# Altium schematic sheet Connectors.SchDoc
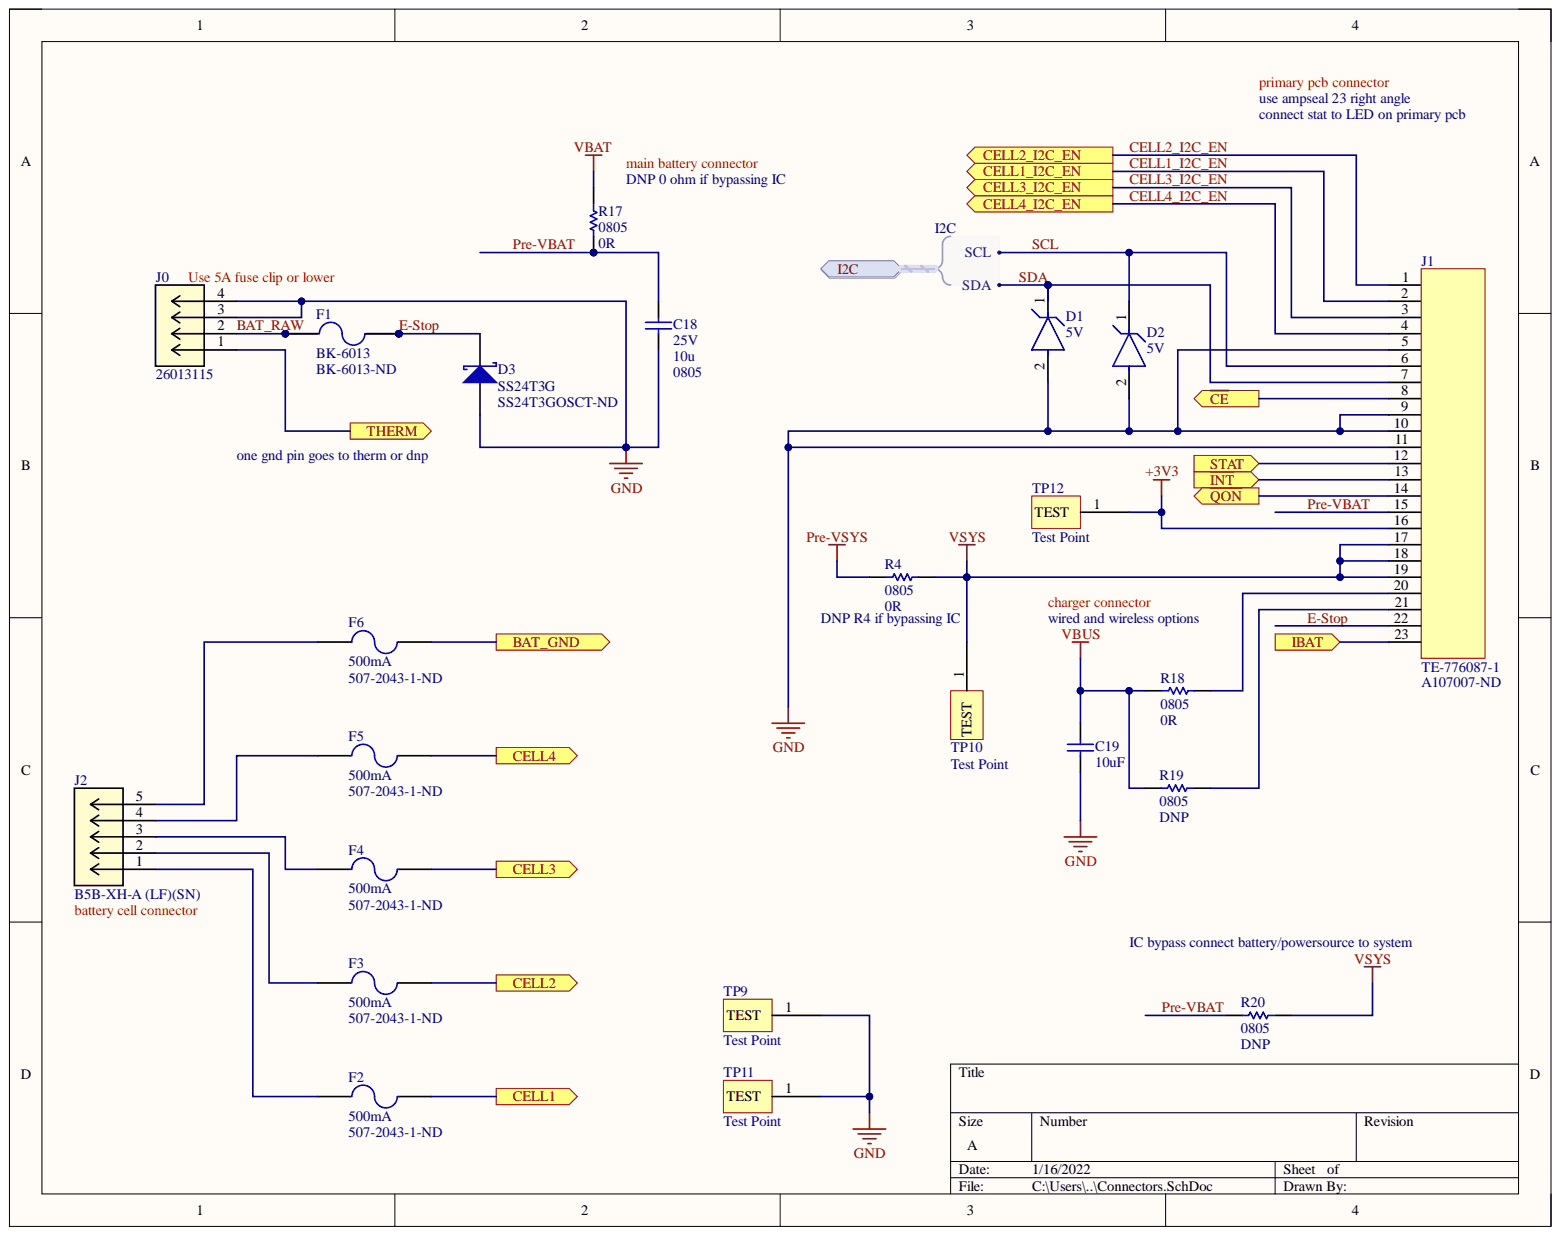
[diagram p0: Connectors.SchDoc]

## Parts
C18  Capacitance: 10u  Package / Case: 0805  Voltage - Rated: 25V  [C0805]  (hidden comment: GRM21BR61E106MA73L)
C19  Capacitance: 10uF  [C0402]  (hidden comment: Capacitor 0402)
D1  Reverse Standoff Voltage: 5V  [FP-SMA-MFG]  (hidden comment: SMAJ5.0A-TR)
D2  Reverse Standoff Voltage: 5V  [FP-SMA-MFG]  (hidden comment: SMAJ5.0A-TR)
D3  SS24T3G  Supplier Part Number 1: SS24T3GOSCT-ND  [DO-214-AA]
F1  BK-6013  Supplier Part Number 1: BK-6013-ND  [BK-6013]
F2  Current Rating: 500mA  Supplier Part Number 1: 507-2043-1-ND  [Bel Fuse Inc. 0679H0500-05]  (hidden comment: Bel Fuse Inc. 0679H0500-05)
F3  Current Rating: 500mA  Supplier Part Number 1: 507-2043-1-ND  [Bel Fuse Inc. 0679H0500-05]  (hidden comment: Bel Fuse Inc. 0679H0500-05)
F4  Current Rating: 500mA  Supplier Part Number 1: 507-2043-1-ND  [Bel Fuse Inc. 0679H0500-05]  (hidden comment: Bel Fuse Inc. 0679H0500-05)
F5  Current Rating: 500mA  Supplier Part Number 1: 507-2043-1-ND  [Bel Fuse Inc. 0679H0500-05]  (hidden comment: Bel Fuse Inc. 0679H0500-05)
F6  Current Rating: 500mA  Supplier Part Number 1: 507-2043-1-ND  [Bel Fuse Inc. 0679H0500-05]  (hidden comment: Bel Fuse Inc. 0679H0500-05)
J0  26013115  [CON_26013115]
J1  TE-776087-1  Supplier Part Number 1: A107007-ND  [TE-776087-1]
J2  B5B-XH-A (LF)(SN)  [CONN_B5B-XH-A (LF)(SN)_JST]
R4  Case Code (Imperial): 0805  Resistance: 0R  [RES0805]  (hidden comment: Resistor 0805)
R17  Case Code (Imperial): 0805  Resistance: 0R  [RES0805]  (hidden comment: Resistor 0805)
R18  Case Code (Imperial): 0805  Resistance: 0R  [RES0805]  (hidden comment: Resistor 0805)
R19  Package / Case: 0805  [RES0805]  (hidden comment: Resistor 0805)
R20  Package / Case: 0805  [RES0805]  (hidden comment: Resistor 0805)
TP9  Test Point  [TEST POINT]
TP10  Test Point  [TEST POINT]
TP11  Test Point  [TEST POINT]
TP12  Test Point  [TEST POINT]

## Ports
BAT_GND, CELL1, CELL1_I2C_EN, CELL2, CELL2_I2C_EN, CELL3, CELL3_I2C_EN, CELL4, CELL4_I2C_EN, I2C, I2C.SCL, I2C.SDA, IBAT, STAT, THERM, ~{CE}, ~{INT}, ~{QON}

## Nets
+3V3: J1.16 TP12.1
GND: C18.1 C19.1 D1.2 D2.2 D3.1 J0.3 J0.4 J1.5 J1.9 J1.10 J1.11 TP9.1 TP11.1
Pre-VSYS: R4.2
VBAT: R17.2
VBUS: C19.2 R18.2 R19.1
VSYS: J1.17 J1.18 J1.19 R4.1 R20.2 TP10.1
~{CE}: J1.8 ; port ~{CE}
~{INT}: J1.13 ; port ~{INT}
~{QON}: J1.14 ; port ~{QON}
BAT_GND: F6.2 ; port BAT_GND
BAT_RAW: F1.1 F1.2 J0.2
CELL1: F2.2 ; port CELL1
CELL1_I2C_EN: J1.2 ; port CELL1_I2C_EN
CELL2: F3.2 ; port CELL2
CELL2_I2C_EN: J1.1 ; port CELL2_I2C_EN
CELL3: F4.2 ; port CELL3
CELL3_I2C_EN: J1.3 ; port CELL3_I2C_EN
CELL4: F5.2 ; port CELL4
CELL4_I2C_EN: J1.4 ; port CELL4_I2C_EN
E-Stop: D3.2 F1.3 F1.4 J1.22
IBAT: J1.23 ; port IBAT
Pre-VBAT: C18.2 J1.15 R17.1 R20.1
SCL: D2.1 J1.6 ; port I2C
SDA: D1.1 J1.7 ; port I2C
STAT: J1.12 ; port STAT
THERM: J0.1 ; port THERM
NetF2_1: F2.1 J2.1
NetF3_1: F3.1 J2.2
NetF4_1: F4.1 J2.3
NetF5_1: F5.1 J2.4
NetF6_1: F6.1 J2.5
NetJ1_20: J1.20 R18.1
NetJ1_21: J1.21 R19.2

## Library data of the parts
C18 = GRM21BR61E106MA73L: CAP SMD 10uF +/-20% 25V 0805; Tolerance: ±20%; Applications: General Purpose; Component Kind: Standard; Library Name: Capacitors.IntLib; Supplier 1: Digi-Key; Supplier Part Number 1: 490-10748-1-ND
C19 = Capacitor 0402: TAIYO YUDEN - AMK105CBJ106MV-F - SMD Multilayer Ceramic Capacitor, 10 &#181;F, 4 V, 0402 [1005 Metric], &#177; 20%, X5R, M Series; Voltage Rating: 4V; Case Code (Imperial): 0402; Case Code (Metric): 1005; Case/Package: 0402; Category: Ceramic Capacitors; Component Kind: Standard; ComponentLink1Description: https://datasheet.ciiva.com/6255/amk105cbj106mv-f-ss-6255363.pdf?src-supplier=Digi-Key; ComponentLink1URL: https://datasheet.ciiva.com/6255/amk105cbj106mv-f-ss-6255363.pdf?src-supplier=Digi-Key; ComponentLink2Description: https://datasheet.ciiva.com/30133/411amk105cbj106mv-f-30133635.pdf?src-supplier=Onlinecomponents.com; ComponentLink2URL: https://datasheet.ciiva.com/30133/411amk105cbj106mv-f-30133635.pdf?src-supplier=Onlinecomponents.com; ComponentLink3Description: https://datasheet.ciiva.com/15916/2amk105cbj106mv-f-15916827.pdf?src-supplier=Onlinecomponents.com; ComponentLink3URL: https://datasheet.ciiva.com/15916/2amk105cbj106mv-f-15916827.pdf?src-supplier=Onlinecomponents.com; Construction: Flat; Dielectric: X5R; Halogen Free: Halogen Free; Height: 0.5mm; Length: 0.039inch; Manufacturer: Taiyo Yuden; Manufacturer Part Number: AMK105CBJ106MV-F; Max Operating Temperature: 85°C; Min Operating Temperature: -55°C; Mount: Surface Mount; Package / Case: 0402; Package Quantity: 1; Packaging: Tape and Reel; Pricing 1: 1=0.26000, 10=0.17900, 50=0.10760, 100=0.09090, 500=0.06220, 1000=0.05502, 2500=0.05262, 5000=0.04784 (USD); REACH SVHC: Unknown; RoHS: Yes; RoHS Compliant: Yes; Series: M; Stock 1: 877687; Supplier 1: Digi-Key; Supplier Part Number 1: 587-3237-1-ND; Termination: SMD/SMT; Thickness: 0.028inch; Tolerance: 20%; Voltage Rating (DC): 4V; Width: 0.02inch; Library Name: Wally_BMS.IntLib
D1 D2 = CMP-12225-000048-1: TVS DIODE 5V 13.4V SMA; Manufacturer: STMicroelectronics; Breakdown Voltage: 6.4V; Clamping Voltage: 9.2V; Direction: Unidirectional; Max Junction Temp: +150°C; Number Of Channels: 1; Peak Pulse Current: 43.5A; Peak Pulse Power: 400W; Standoff Height: 0.05mm; Automotive: No; Category: Diode; Component Kind: Standard; ComponentLink2Description: Datasheet; ComponentLink2URL: https://www.st.com/resource/en/datasheet/smaj.pdf; Device Class L1: Circuit Protection; Device Class L2: TVS Diodes; Device Class L3: unset; Digikey Description: TVS DIODE 5V 13.4V SMA; Height: 2.65mm; Lead Free: Yes; Library Name: Altium Content Vault; Manufacturer Part Number: SMAJ5.0A-TR; Mouser Description: Transient Voltage Suppressor, TVS, Transil SMAJ Series, Unidirectional, 5 V, DO-214AC, 2, 6.4 V; Octopart: https://octopart.com/smaj5.0a-tr-stmicroelectronics-347063; Package: SMA; RoHS: TRUE; Temperature: -55°C to +150°C; Temperature Range High: +150°C; Temperature Range Low: -55°C
D3 = SS24T3G: Schottky Diode; Code_JEDEC: DO-214-AA; Component Kind: Standard; LatestRevisionDate: 17-Jul-2002; LatestRevisionNote: Re-released for DXP Platform.; Library Name: Diodes.IntLib; PackageReference: SMB; Published: 8-Jun-2000; Supplier 1: Digi-Key; Publisher: Altium Limited
F1 = BK-6013: FUSE HOLDER BLADE PCB; Component Kind: Standard; Library Name: Fuses.IntLib; Supplier 1: Digi-Key
F2-F6 = Bel Fuse Inc. 0679H0500-05: FUSE BRD MNT 500MA 350VAC 72VDC; Breaking Capacity @ Rated Voltage: 50A AC, 100A DC; Fuse Type Cartridge: Board Mount (Cartridge Style Excluded); Melting I²t: 0.08; Voltage Rating - AC: 350V; Voltage Rating - DC: 72V; Component Kind: Standard; Library Name: Fuses.IntLib; Manufacturer: Bel Fuse Inc.; Manufacturer Part Number: 0679H0500-05; Supplier 1: Digi-Key
J0 = 26013115: Conn Power HDR 4 POS 4.2mm Solder RA Thru-Hole 4 Terminal 1 Port Bag; Manufacturer: Molex; Mfr_Name: Molex Connector Corporation; Current Rating: 13A; Number of Contacts: 4; Number of Ports: 1; Row Spacing: 0.165inch; Voltage Rating: 600V; Category: Headers and Wire Housings; Color: Natural; Component Kind: Standard; ComponentLink1Description: https://datasheet.ciiva.com/6814/039301100-sd-6814020.pdf?src-supplier=Digi-Key; ComponentLink1URL: https://datasheet.ciiva.com/6814/039301100-sd-6814020.pdf?src-supplier=Digi-Key; ComponentLink2Description: https://datasheet.ciiva.com/30235/0026013115-pcb-headers-30235692.pdf?src-supplier=Onlinecomponents.com; ComponentLink2URL: https://datasheet.ciiva.com/30235/0026013115-pcb-headers-30235692.pdf?src-supplier=Onlinecomponents.com; ComponentLink3Description: https://datasheet.ciiva.com/16654/0026013115-pcb-headers-16654666.pdf?src-supplier=Avnet+Europe; ComponentLink3URL: https://datasheet.ciiva.com/16654/0026013115-pcb-headers-16654666.pdf?src-supplier=Avnet+Europe; Contact Gender: Male; Contact Material: Brass; Contact Plating: Gold; Contact Type: Male Pin; ELV: Compliant; Fastening Type: Ramp; Flammability Rating: UL94 V-2; Housing Material: Nylon; Length: 9.6mm; Manufacturer Part Number: 26-01-3115; Manufacturer_Part_Number: 26013115; Material: Brass; Max Operating Temperature: 105°C; Min Operating Temperature: -40°C; Mount: Through Hole; Number of Positions: 4; Number of Rows: 2; Orientation: Right Angle; Package Quantity: 1320; Packaging: Tray; Pitch: 4.2mm; Pricing 1: 1=1.30000, 10=1.07300, 100=0.89400, 1000=0.71518, 5000=0.62578, 10000=0.59449 (USD); Radiation Hardening: No; REACH SVHC: No SVHC; RefDes: RefDes; RoHS: Yes; Series: Mini-Fit® Jr™ 5569; Stock 1: 12454; Supplier 1: Digi-Key; Supplier Part Number 1: WM13112-ND; Termination: Solder; Type: DEV; Copyright: Copyright (C) 2021 Ultra Librarian. All rights reserved.; Library Name: Wally_BMS.IntLib
J1 = TE-776087-1: CONN HDR RTANG 23POS W/GASKT TIN; Component Kind: Standard; Library Name: Connectors and Headers.IntLib; Supplier 1: Digi-Key
J2 = B5B-XH-A (LF)(SN): No Description Available; Mfr_Name: JST; Component Kind: Standard; Manufacturer_Part_Number: B5B-XH-A (LF)(SN); RefDes: RefDes; Type: DEV; Copyright: Copyright (C) 2021 Ultra Librarian. All rights reserved.; Library Name: Wally_BMS.IntLib
R4 R17 R18 = Resistor 0805: Res Thick Film 0805 0 Ohm Jumper 1/8W Molded SMD Paper T/R; Manufacturer: Stackpole Electronics; Manufacturer Part Number: RMCF0805ZT0R00; Max Power Dissipation: 125mW; Case Code (Metric): 2012; Case/Package: 0805; Category: Chip SMD Resistors; Component Kind: Standard; ComponentLink1Description: https://datasheet.ciiva.com/15193/getdatasheetpartid-360417-15193658.pdf?src-supplier=Verical; ComponentLink1URL: https://datasheet.ciiva.com/15193/getdatasheetpartid-360417-15193658.pdf?src-supplier=Verical; Construction: Rectangular; Contact Plating: Tin,Matte; Depth: 1.25mm; Features: Automotive AEC-Q200; Height: 0.026inch; Lead Free: Lead Free; Length: 2mm; Max Operating Temperature: 155°C; Military Standard: Not; Min Operating Temperature: -55°C; Mount: Surface Mount; Number of Terminations: 2; Package / Case: 0805; Packaging: Tape and Reel; Power Rating: 125mW; Pricing 1: 1=0.10000, 10=0.01700, 25=0.01280, 50=0.00960, 100=0.00710, 250=0.00540, 500=0.00432, 1000=0.00318 (USD); Radiation Hardening: No; Resistor Type: Thick Film; RoHS: Yes; RoHS Compliant: Yes; Series: RMCF; Size Code: 0805; Stock 1: 3965473; Supplier 1: Digi-Key; Supplier Part Number 1: RMCF0805ZT0R00CT-ND; Width: 0.049inch; Library Name: Wally_BMS.IntLib
R19 R20 = Resistor 0805: DNP; Component Kind: Standard; Resistance: DNP; Library Name: Wally_BMS.IntLib
TP9-TP12 = Test Point: Component Kind: Standard; Library Name: Wally_BMS.IntLib
